# Altium schematic sheet [09]-Digital-IO.SchDoc
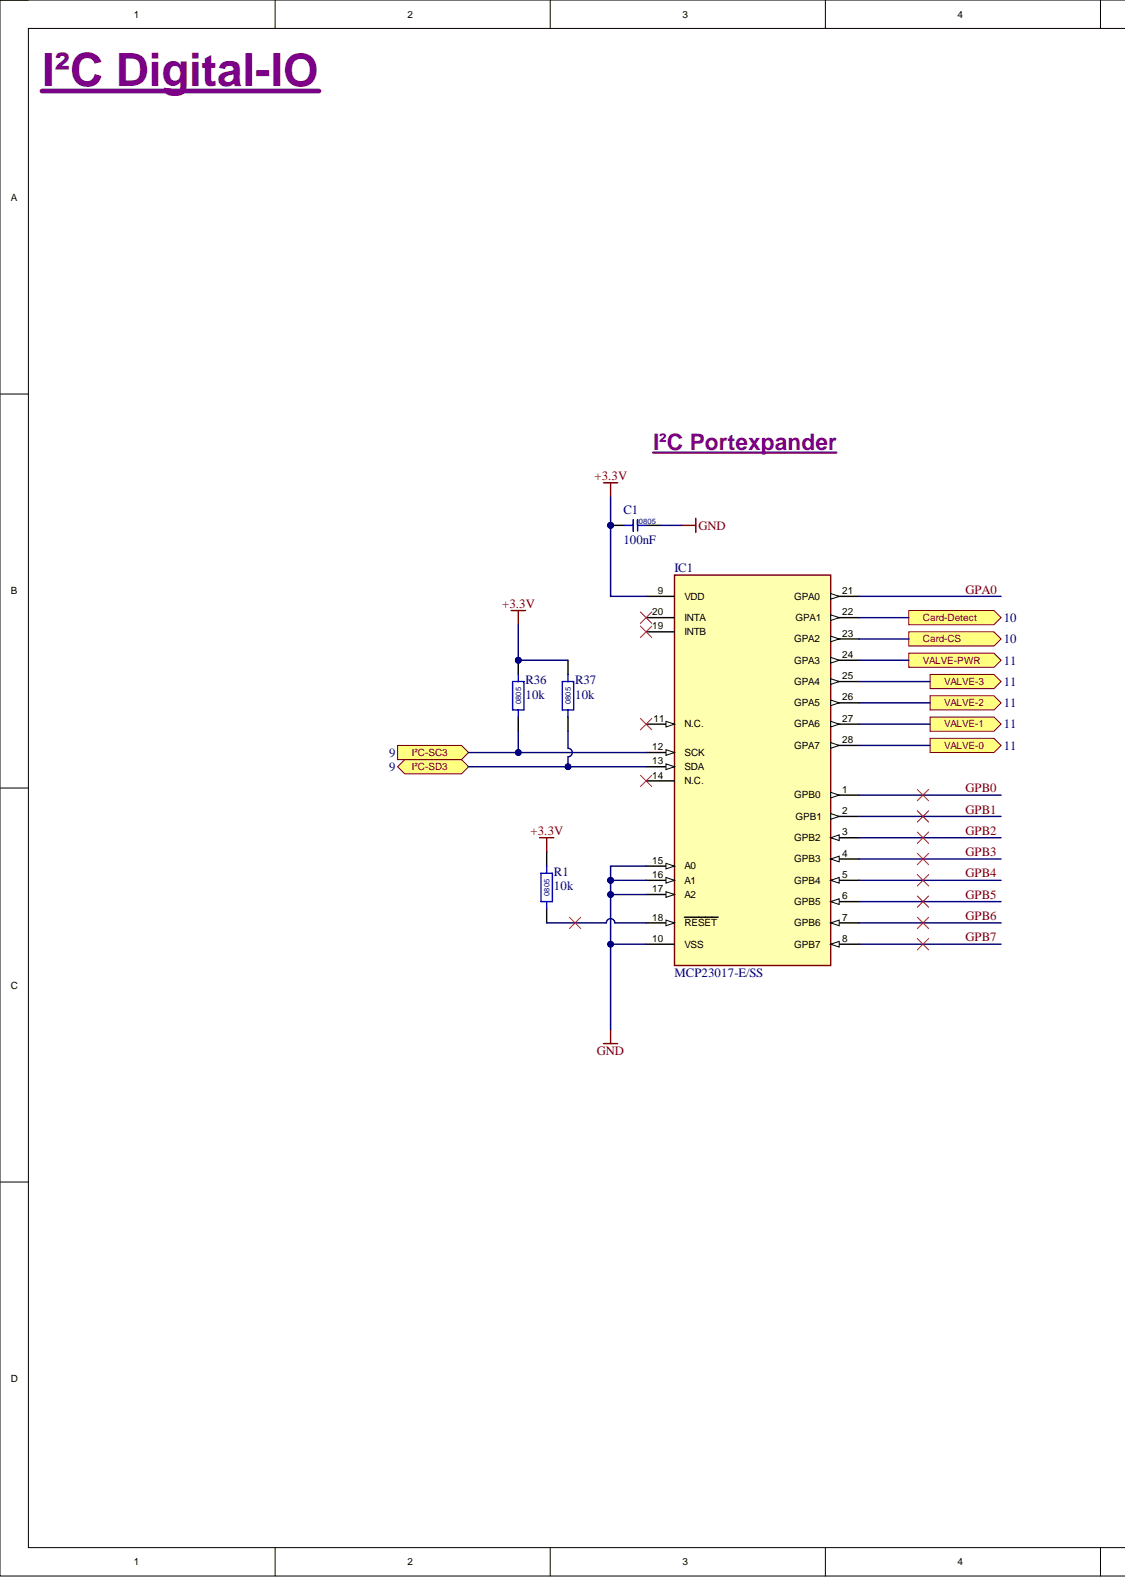
[diagram p0: [09]-Digital-IO.SchDoc, left]
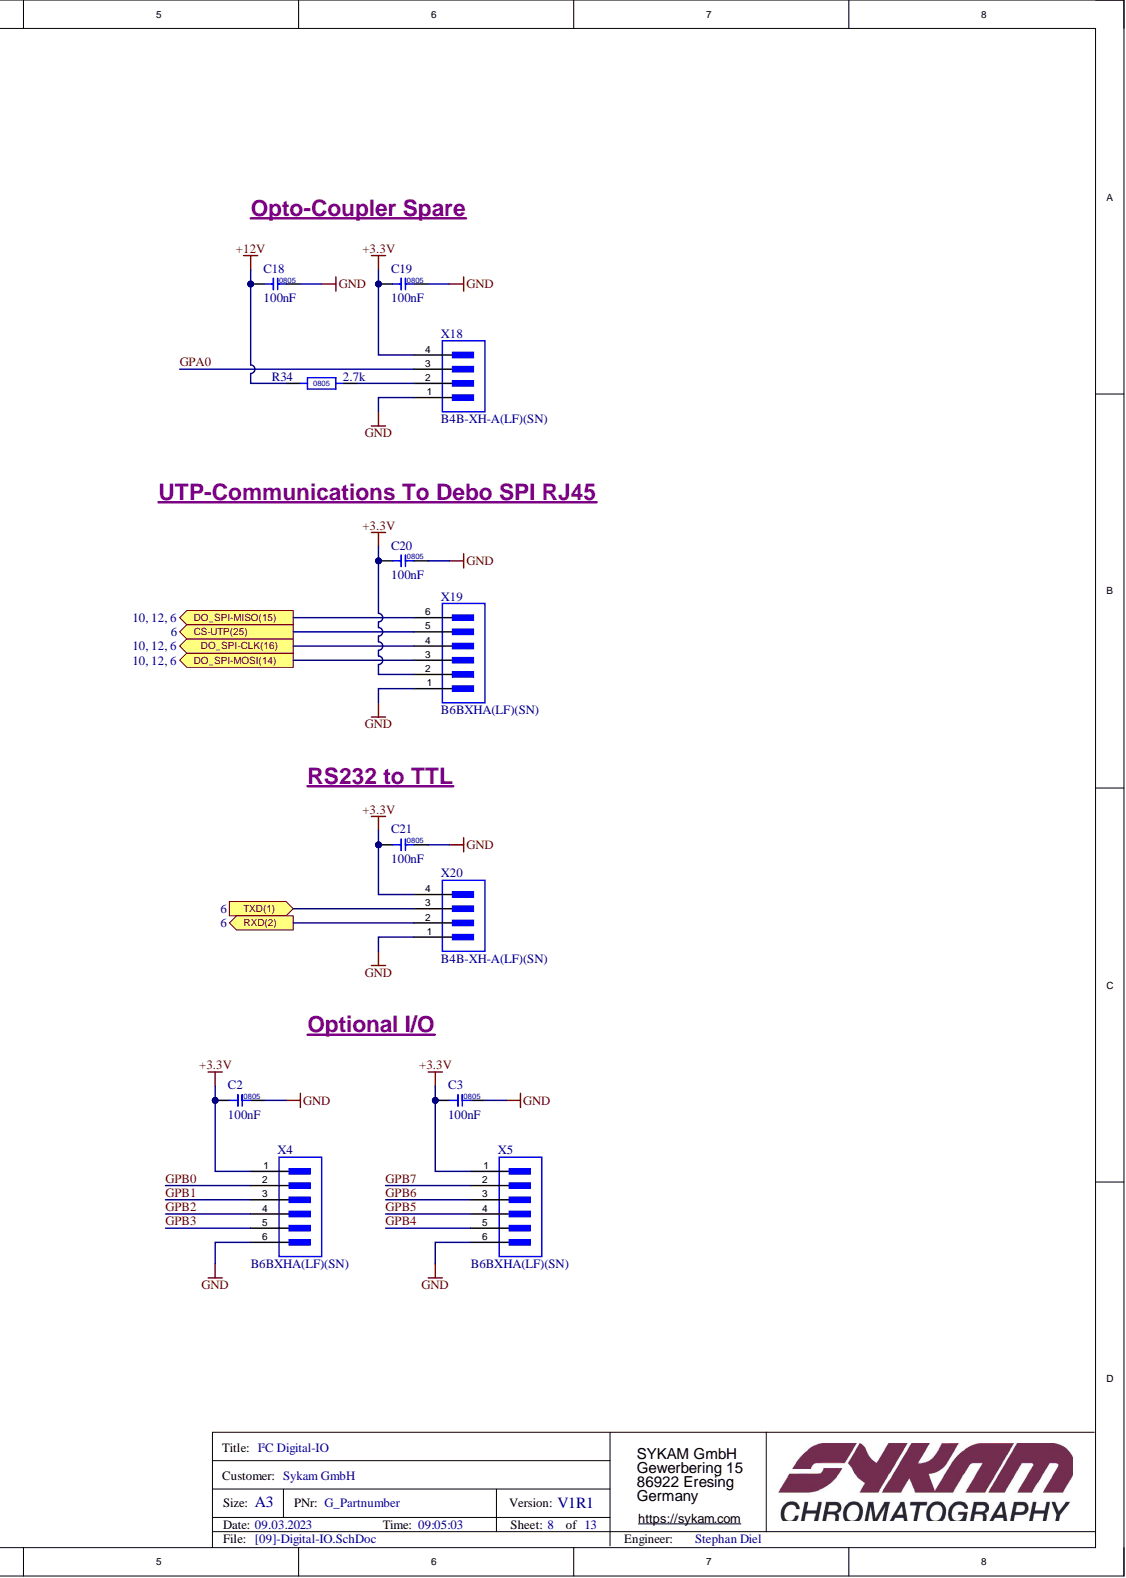
[diagram p1: [09]-Digital-IO.SchDoc, right]

## Parts
C1  100nF  Case Code (Imperial): 0805  [C0805]
C2  100nF  Case Code (Imperial): 0805  [C0805]
C3  100nF  Case Code (Imperial): 0805  [C0805]
C18  100nF  Case Code (Imperial): 0805  [C0805]
C19  100nF  Case Code (Imperial): 0805  [C0805]
C20  100nF  Case Code (Imperial): 0805  [C0805]
C21  100nF  Case Code (Imperial): 0805  [C0805]
IC1  MCP23017-E/SS  [IC_MCP23017-E/SS_SSOP28]
R1  10k  Case Code (Imperial): 0805  [R0805]
R34  2.7k  Case Code (Imperial): 0805  [R0805]
R36  10k  Case Code (Imperial): 0805  [R0805]
R37  10k  Case Code (Imperial): 0805  [R0805]
X4  B6BXHA(LF)(SN)  [B6BXHA(LF)(SN)]
X5  B6BXHA(LF)(SN)  [B6BXHA(LF)(SN)]
X18  B4B-XH-A(LF)(SN)  [CON_B4B-XH-A(LF)(SN)]
X19  B6BXHA(LF)(SN)  [B6BXHA(LF)(SN)]
X20  B4B-XH-A(LF)(SN)  [CON_B4B-XH-A(LF)(SN)]

## Ports
Card-CS, Card-Detect, CS-UTP(25), DO_SPI-CLK(16), DO_SPI-MISO(15), DO_SPI-MOSI(14), I²C-SC3, I²C-SD3, RXD(2), TXD(1), VALVE-0, VALVE-1, VALVE-2, VALVE-3, VALVE-PWR

## Nets
+3.3V: C1.1 C2.1 C3.1 C19.1 C20.1 C21.1 IC1.9(VDD) R1.2 R36.2 R37.2 X4.1 X5.1 X18.4 X19.2 X20.4
+12V: C18.1 R34.1
GND: C1.2 C2.2 C3.2 C18.2 C19.2 C20.2 C21.2 IC1.10(VSS) IC1.15(A0) IC1.16(A1) IC1.17(A2) X4.6 X5.6 X18.1 X19.1 X20.1
Card-CS: IC1.23(GPA2) ; port Card-CS
Card-Detect: IC1.22(GPA1) ; port Card-Detect
CS-UTP(25): X19.5 ; port CS-UTP(25)
DO_SPI-CLK(16): X19.4 ; port DO_SPI-CLK(16)
DO_SPI-MISO(15): X19.6 ; port DO_SPI-MISO(15)
DO_SPI-MOSI(14): X19.3 ; port DO_SPI-MOSI(14)
GPA0: IC1.21(GPA0) X18.3
GPB0: IC1.1(GPB0) X4.2
GPB1: IC1.2(GPB1) X4.3
GPB2: IC1.3(GPB2) X4.4
GPB3: IC1.4(GPB3) X4.5
GPB4: IC1.5(GPB4) X5.5
GPB5: IC1.6(GPB5) X5.4
GPB6: IC1.7(GPB6) X5.3
GPB7: IC1.8(GPB7) X5.2
I²C-SC3: IC1.12(SCK) R36.1 ; port I²C-SC3
I²C-SD3: IC1.13(SDA) R37.1 ; port I²C-SD3
RXD(2): X20.2 ; port RXD(2)
TXD(1): X20.3 ; port TXD(1)
VALVE-0: IC1.28(GPA7) ; port VALVE-0
VALVE-1: IC1.27(GPA6) ; port VALVE-1
VALVE-2: IC1.26(GPA5) ; port VALVE-2
VALVE-3: IC1.25(GPA4) ; port VALVE-3
VALVE-PWR: IC1.24(GPA3) ; port VALVE-PWR
NetIC1_18: IC1.18(RESET) R1.1
NetR34_2: R34.2 X18.2

Not connected: IC1 11(N.C.) 14(N.C.) 19(INTB) 20(INTA)

## Library data of the parts
C1-C3 C18-C21 = C_100nF_CC0805KRX7R9BB104: CAP CER 0.1UF 50V X7R 0805; Manufacturer: Yageo; Insulation Resistance: 100GR; Material: Ceramic; PCBChecked: initials after Checked; Tolerance: 10%; Capacitance: 100nF; Case Code (Metric): 2012; Case/Package: 0805; Category: Ceramic Capacitors; Component Kind: Standard; ComponentLink1Description: https://datasheet.ciiva.com/11786/0900766b80d84f1d-11786009.pdf?src-supplier=RSComponents; ComponentLink1URL: https://datasheet.ciiva.com/11786/0900766b80d84f1d-11786009.pdf?src-supplier=RSComponents; ComponentLink2Description: https://datasheet.ciiva.com/11464/upy-gphc-x7r-6-3v-to-50v-8-11464757.pdf?src-supplier=Avnet; ComponentLink2URL: https://datasheet.ciiva.com/11464/upy-gphc-x7r-6-3v-to-50v-8-11464757.pdf?src-supplier=Avnet; ComponentLink3Description: https://datasheet.ciiva.com/28450/1489800-28450805.pdf?src-supplier=Element14; ComponentLink3URL: https://datasheet.ciiva.com/28450/1489800-28450805.pdf?src-supplier=Element14; ComponentLink4Description: https://datasheet.ciiva.com/155/mlccorderingcode-155929.pdf?src-supplier=Digi-Key; ComponentLink4URL: https://datasheet.ciiva.com/155/mlccorderingcode-155929.pdf?src-supplier=Digi-Key; ComponentLink5Description: https://datasheet.ciiva.com/155/mlccorderingcode-155930.pdf?src-supplier=Digi-Key; ComponentLink5URL: https://datasheet.ciiva.com/155/mlccorderingcode-155930.pdf?src-supplier=Digi-Key; ComponentLink6Description: https://datasheet.ciiva.com/155/mlccorderingcode-155931.pdf?src-supplier=Digi-Key; ComponentLink6URL: https://datasheet.ciiva.com/155/mlccorderingcode-155931.pdf?src-supplier=Digi-Key; ComponentLink7Description: https://datasheet.ciiva.com/46393/1734573-46393307.pdf?src-supplier=Element14; ComponentLink7URL: https://datasheet.ciiva.com/46393/1734573-46393307.pdf?src-supplier=Element14; ComponentLink8Description: https://datasheet.ciiva.com/16625/upy-gphc-x7r-6-3v-to-50v-8-16625549.pdf?src-supplier=Avnet+Europe; ComponentLink8URL: https://datasheet.ciiva.com/16625/upy-gphc-x7r-6-3v-to-50v-8-16625549.pdf?src-supplier=Avnet+Europe; ComponentLink9Description: https://datasheet.ciiva.com/29242/445210-da-01-en-kerko-chip-0805-x7r-0-1uf-10proz-50vg-29242074.pdf?src-supplier=Conrad; ComponentLink9URL: https://datasheet.ciiva.com/29242/445210-da-01-en-kerko-chip-0805-x7r-0-1uf-10proz-50vg-29242074.pdf?src-supplier=Conrad; Depth: 1.25mm; Dielectric: X7R; Halogen Free: Halogen Free; Height: 0.85mm; IPC7352C: done; Lead Free: Lead Free; Length: 2mm; Manufacturer Part Number: CC0805KRX7R9BB104; Max Operating Temperature: 125°C; Min Operating Temperature: -55°C; Mount: Surface Mount; Number of Pins: 2; Package Quantity: 1; Packaging: Tape and Reel; Radiation Hardening: No; REACH SVHC: No SVHC; RoHS: Yes; Stock 1: 7118979; Supplier 1: Digi-Key; Supplier Part Number 1: 311-1140-6-ND; Termination: SMD/SMT; Thickness: 0.033inch; Voltage: 50V; Voltage Rating: 50V; Width: 0.049inch; Library Name: ToradexMainBoard-V0I1.SCHLIB; SCHChecked: Initials after checked
IC1 = IC_MCP23017-E/SS: I²C Bus IC Operating temperature: -40...+125 °C Power supply: 1.8...5.5 V Package: SSOP-20; Manufacturer: Microchip; Frequency: 1.7MHz; Interface: I2C,Serial; Max Power Dissipation: 700mW; Max Supply Voltage: 5.5V; Min Supply Voltage: 4.5V; Nominal Supply Current: 1mA; Number of Bits: 16; Number of Channels: 1; Output Current: 25mA; Output Type: PUSH-PULL; Case/Package: SSOP; Category: Interface ICs; Component Kind: Standard; ComponentLink1Description: https://datasheet.ciiva.com/1495/12179-1495878.pdf?src-supplier=Element14; ComponentLink1URL: https://datasheet.ciiva.com/1495/12179-1495878.pdf?src-supplier=Element14; ComponentLink2Description: https://datasheet.ciiva.com/3149/21952b-3149160.pdf?src-supplier=Avnet; ComponentLink2URL: https://datasheet.ciiva.com/3149/21952b-3149160.pdf?src-supplier=Avnet; ComponentLink3Description: https://datasheet.ciiva.com/1780/21952b-1780093.pdf?src-supplier=Digi-Key; ComponentLink3URL: https://datasheet.ciiva.com/1780/21952b-1780093.pdf?src-supplier=Digi-Key; ComponentLink4Description: https://datasheet.ciiva.com/16454/filehandler-aspxddocname-en023709-16454261.pdf?src-supplier=Future+Electronics; ComponentLink4URL: https://datasheet.ciiva.com/16454/filehandler-aspxddocname-en023709-16454261.pdf?src-supplier=Future+Electronics; Lead Free: Lead Free; Manufacturer Part Number: MCP23017-E/SS; Max Frequency: 1.7MHz; Max Operating Temperature: 125°C; Min Operating Temperature: -40°C; Mount: Surface Mount; Number of I/Os: 16; Number of Pins: 28; Packaging: Rail/Tube; Pricing 1: 1=2,13000, 25=1,60000, 100=1,57000 (EUR); Reach SVHC Compliant: Yes; RoHS: Yes; RoHS Compliant: Yes; Series: -; Stock 1: 15856; Supplier 1: Farnell; Supplier Part Number 1: 1467674; Supply Current: 1mA; Supply Voltage-Nom: 5.5V; Weight: 0.080001oz; Library Name: ToradexMainBoard-V0I1.SCHLIB
R1 R36 R37 = R_10k_RC0805FR-0710KL: RES SMD 10K OHM 1% 1/8W 0805; Manufacturer: Yageo; Max Power Dissipation: 125mW; PCBChecked: initials after Checked; Resistance: 10kR; Temperature Coefficient: 100ppm/°C; Voltage Rating: 150V; Case Code (Metric): 2012; Case/Package: 0805; Category: Chip SMD Resistors; Component Kind: Standard; ComponentLink1Description: https://datasheet.ciiva.com/11808/0900766b8106beab-11808151.pdf?src-supplier=RSComponents; ComponentLink1URL: https://datasheet.ciiva.com/11808/0900766b8106beab-11808151.pdf?src-supplier=RSComponents; ComponentLink2Description: https://datasheet.ciiva.com/12669/rc0805-12669688.pdf?src-supplier=Mouser; ComponentLink2URL: https://datasheet.ciiva.com/12669/rc0805-12669688.pdf?src-supplier=Mouser; ComponentLink3Description: https://datasheet.ciiva.com/2456/pyu-r-int-thick-7-2456973.pdf?src-supplier=Digi-Key; ComponentLink3URL: https://datasheet.ciiva.com/2456/pyu-r-int-thick-7-2456973.pdf?src-supplier=Digi-Key; ComponentLink4Description: https://datasheet.ciiva.com/16747/rc0805-16747087.pdf?src-supplier=Future+Electronics; ComponentLink4URL: https://datasheet.ciiva.com/16747/rc0805-16747087.pdf?src-supplier=Future+Electronics; ComponentLink5Description: https://datasheet.ciiva.com/46447/444164-46447563.pdf?src-supplier=Element14; ComponentLink5URL: https://datasheet.ciiva.com/46447/444164-46447563.pdf?src-supplier=Element14; ComponentLink6Description: https://datasheet.ciiva.com/2456/pyu-r-int-thick-7-2456972.pdf?src-supplier=Digi-Key; ComponentLink6URL: https://datasheet.ciiva.com/2456/pyu-r-int-thick-7-2456972.pdf?src-supplier=Digi-Key; ComponentLink7Description: https://datasheet.ciiva.com/2456/pyu-r-int-thick-7-2456974.pdf?src-supplier=Digi-Key; ComponentLink7URL: https://datasheet.ciiva.com/2456/pyu-r-int-thick-7-2456974.pdf?src-supplier=Digi-Key; Composition: Thick Film; Depth: 1.25mm; Features: Moisture Resistant; Height: 0.024inch; IPC7352C: done; Lead Free: Lead Free; Length: 2mm; Manufacturer Part Number: RC0805FR-0710KL; Max Operating Temperature: 155°C; Military Standard: Not; Min Operating Temperature: -55°C; Number of Terminations: 2; Package Quantity: 1; Packaging: Tape and Reel; Power Rating: 125mW; REACH SVHC: Unknown; RoHS: Yes; Stock 1: 31284302; Supplier 1: Digi-Key; Supplier Part Number 1: 311-10.0KCRCT-ND; Termination: SMD/SMT; Tolerance: 1%; Width: 0.049inch; Library Name: ToradexMainBoard-V0I1.SCHLIB; SCHChecked: Initials after checked
R34 = R_2.7k_RT0805FRE072K7L: YAGEO (PHYCOMP)   RT0805FRE072K7L   Surface Mount Chip Resistor, Thin Film, RT Series, 2.7 kohm, 125 mW,  1%, 150 V; Max Power Dissipation: 125mW; Resistance: 2.7kR; Temperature Coefficient: 50ppm/°C; Voltage Rating: 150V; Case Code (Metric): 2012; Case/Package: 0805; Category: Chip SMD Resistors; Component Kind: Standard; ComponentLink1Description: https://datasheet.ciiva.com/2561/pyu-rt-1-to-0-05-rohs-l-5-2561137.pdf?src-supplier=Digi-Key; ComponentLink1URL: https://datasheet.ciiva.com/2561/pyu-rt-1-to-0-05-rohs-l-5-2561137.pdf?src-supplier=Digi-Key; ComponentLink2Description: https://datasheet.ciiva.com/7942/1392914-7942127.pdf?src-supplier=Element14; ComponentLink2URL: https://datasheet.ciiva.com/7942/1392914-7942127.pdf?src-supplier=Element14; Construction: Rectangular; Contact Plating: Tin,Matte; Depth: 1.25mm; Height: 0.024inch; Lead Free: Lead Free; Length: 0.079inch; Manufacturer: Yageo; Manufacturer Part Number: RT0805FRE072K7L; Max Operating Temperature: 125°C; Military Standard: Not; Min Operating Temperature: -55°C; Number of Terminations: 2; Package Quantity: 5000; Packaging: Tape and Reel; Power Rating: 125mW; Pricing 1: 10=0,11500, 100=0,03970, 500=0,03190, 1000=0,02410 (EUR); Radiation Hardening: No; REACH SVHC: Unknown; Resistance Tolerance: 1%; Resistor Type: Thin Film; RoHS: Yes; RoHS Compliant: Yes; Series: RT; Stock 1: 4023; Supplier 1: Farnell; Supplier Part Number 1: 1500699; Tolerance: 1%; Voltage Rating (DC): 150V; Width: 0.049inch; Library Name: ToradexMainBoard-V0I1.SCHLIB
X4 X5 X19 = CON_6pol_B6BXHA(LF)(SN): CONN HEADER VERT 6POS 2.5MM; Manufacturer: JST; Connector Type: Male Pin; Contact Resistance: 20mR; Current Rating: 3A; Insulation Resistance: 1GR; Max Voltage Rating (AC): 250V; Number of Contacts: 6; Wire/Cable Gauge: 22AWG; Category: FFC / FPC; Color: Natural; Component Kind: Standard; ComponentLink1Description: https://datasheet.ciiva.com/26894/getdatasheetpartid-424647-26894806.pdf?src-supplier=Verical; ComponentLink1URL: https://datasheet.ciiva.com/26894/getdatasheetpartid-424647-26894806.pdf?src-supplier=Verical; ComponentLink2Description: https://datasheet.ciiva.com/26894/getdatasheetpartid-641012-26894489.pdf?src-supplier=Verical; ComponentLink2URL: https://datasheet.ciiva.com/26894/getdatasheetpartid-641012-26894489.pdf?src-supplier=Verical; ComponentLink3Description: https://datasheet.ciiva.com/20207/523653-20207177.pdf?src-supplier=Rapid+Electronics; ComponentLink3URL: https://datasheet.ciiva.com/20207/523653-20207177.pdf?src-supplier=Rapid+Electronics; ComponentLink4Description: https://datasheet.ciiva.com/16397/b6b-xh-alfsn-16397116.pdf?src-supplier=Future+Electronics; ComponentLink4URL: https://datasheet.ciiva.com/16397/b6b-xh-alfsn-16397116.pdf?src-supplier=Future+Electronics; ComponentLink5Description: https://datasheet.ciiva.com/712/exh-712511.pdf?src-supplier=Digi-Key; ComponentLink5URL: https://datasheet.ciiva.com/712/exh-712511.pdf?src-supplier=Digi-Key; Contact Material: Brass; Contact Plating: Tin,Lead,Copper; Depth: 5.75mm; Housing Color: White; Housing Material: Polyamide; Lead Free: Lead Free; Lead Pitch: 2.5mm; Length: 17.4mm; Manufacturer Part Number: B6BXHA(LF)(SN); Max Current Rating: 3A; Max Operating Temperature: 85°C; Max Voltage Rating (DC): 250V; Min Operating Temperature: -25°C; Mount: Through Hole; Number of Positions: 6; Number of Rows: 1; Orientation: Straight; Package Quantity: 500; Packaging: Bulk; Pitch: 2.5mm; REACH SVHC: No SVHC; RoHS: Yes; Supplier 1: Digi-Key; Supplier Part Number 1: 455-2271-ND; Termination: Press-Fit; Voltage Rating: 250V; Voltage Rating (AC): 250V; Library Name: ToradexMainBoard-V0I1.SCHLIB
X18 X20 = CON_4pol_B4B-XH-A(LF)(SN): JST (JAPAN SOLDERLESS TERMINALS) - B4B-XH-A (LF)(SN) - Wire-To-Board-Steckverbinder, vertikal, 2.5 mm, 4 Kontakt(e), Stiftleiste, Baureihe XH; Connector Type: Male Pin; Contact Resistance: 20mR; Current Rating: 3A; Gender: Male; Insulation Resistance: 1GR; Max Voltage Rating (AC): 250V; Number of Contacts: 4; Pitch: 2.5mm; Wire/Cable Gauge: 22AWG; Category: FFC / FPC; Color: Natural; Component Kind: Standard; ComponentLink1Description: https://datasheet.ciiva.com/26894/getdatasheetpartid-455616-26894787.pdf?src-supplier=Verical; ComponentLink1URL: https://datasheet.ciiva.com/26894/getdatasheetpartid-455616-26894787.pdf?src-supplier=Verical; ComponentLink2Description: https://datasheet.ciiva.com/26924/getdatasheetpartid-640571-26924035.pdf?src-supplier=Verical; ComponentLink2URL: https://datasheet.ciiva.com/26924/getdatasheetpartid-640571-26924035.pdf?src-supplier=Verical; ComponentLink3Description: https://datasheet.ciiva.com/16203/b4b-xh-alfsn-16203642.pdf?src-supplier=Future+Electronics; ComponentLink3URL: https://datasheet.ciiva.com/16203/b4b-xh-alfsn-16203642.pdf?src-supplier=Future+Electronics; ComponentLink4Description: https://datasheet.ciiva.com/726/exh-726404.pdf?src-supplier=Digi-Key; ComponentLink4URL: https://datasheet.ciiva.com/726/exh-726404.pdf?src-supplier=Digi-Key; Contact Material: Brass; Contact Plating: Tin,Copper,Lead; Depth: 5.75mm; Housing Color: White; Housing Material: Polyamide; Lead Free: Lead Free; Lead Pitch: 2.5mm; Length: 12.4mm; Manufacturer: JST; Manufacturer Part Number: B4B-XH-A(LF)(SN); Material: Polyamide; Max Current Rating: 3A; Max Operating Temperature: 85°C; Max Voltage Rating (DC): 250V; Min Operating Temperature: -25°C; Mount: Through Hole; Number of Positions: 4; Number of Rows: 1; Orientation: Straight; Package Quantity: 500; Packaging: Bulk; REACH SVHC: No SVHC; RoHS: Yes; Supplier 1: Newark; Supplier Part Number 1: 73M9436; Termination: Press-Fit; Voltage Rating: 250V; Voltage Rating (AC): 250V; Library Name: ToradexMainBoard-V0I1.SCHLIB
